# Altium schematic sheet Current_and_Relay_Sense.SchDoc
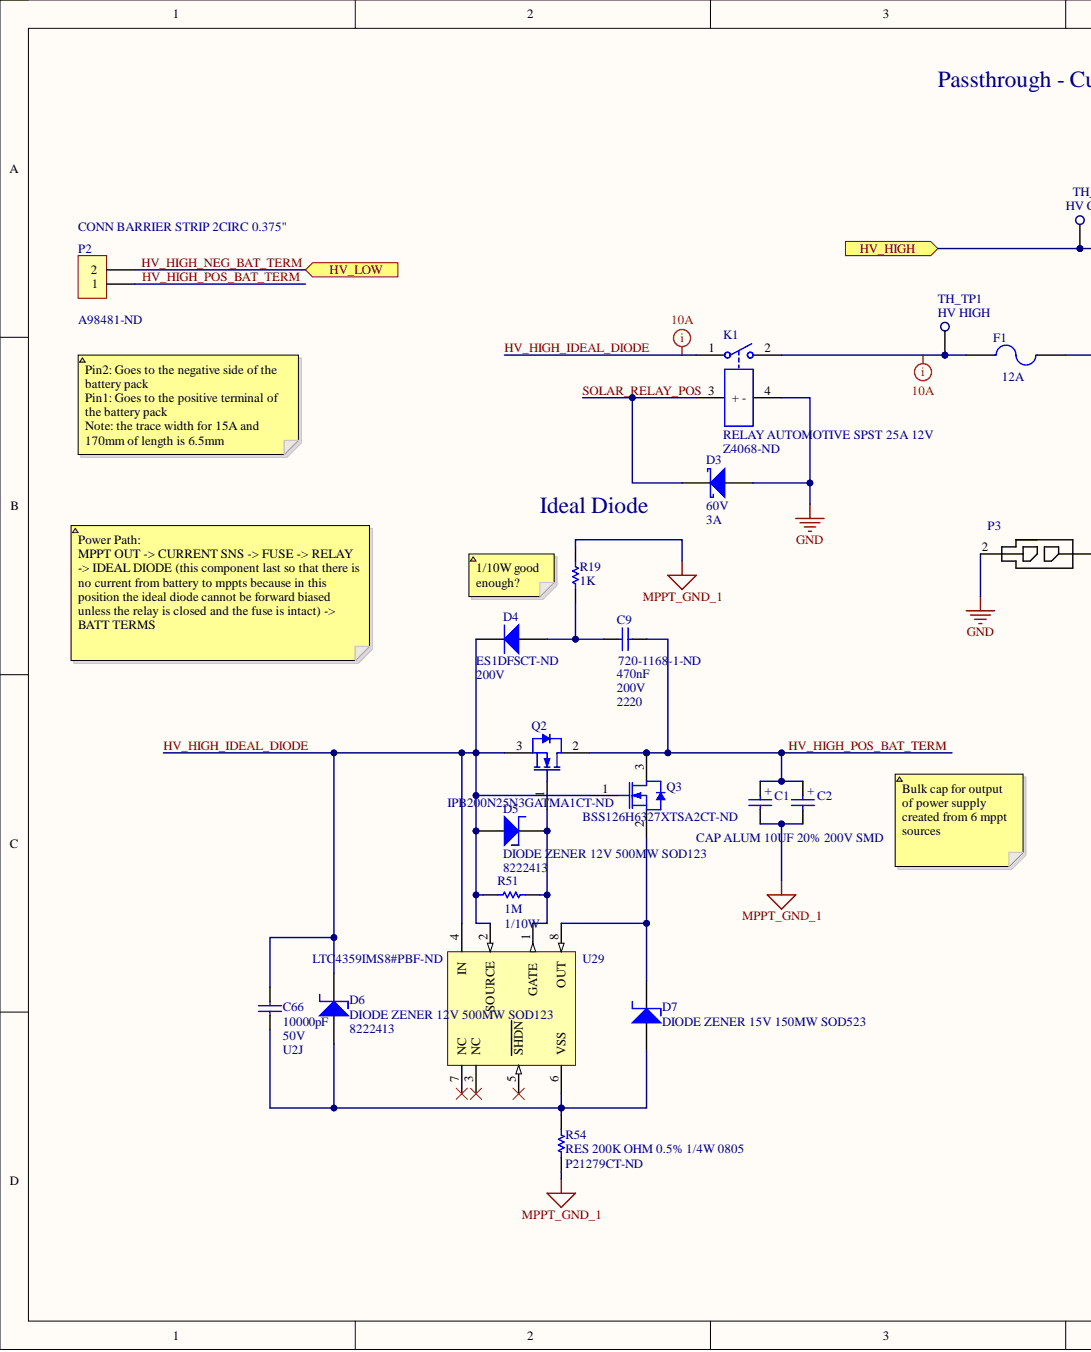
[diagram p0: Current_and_Relay_Sense.SchDoc, left]
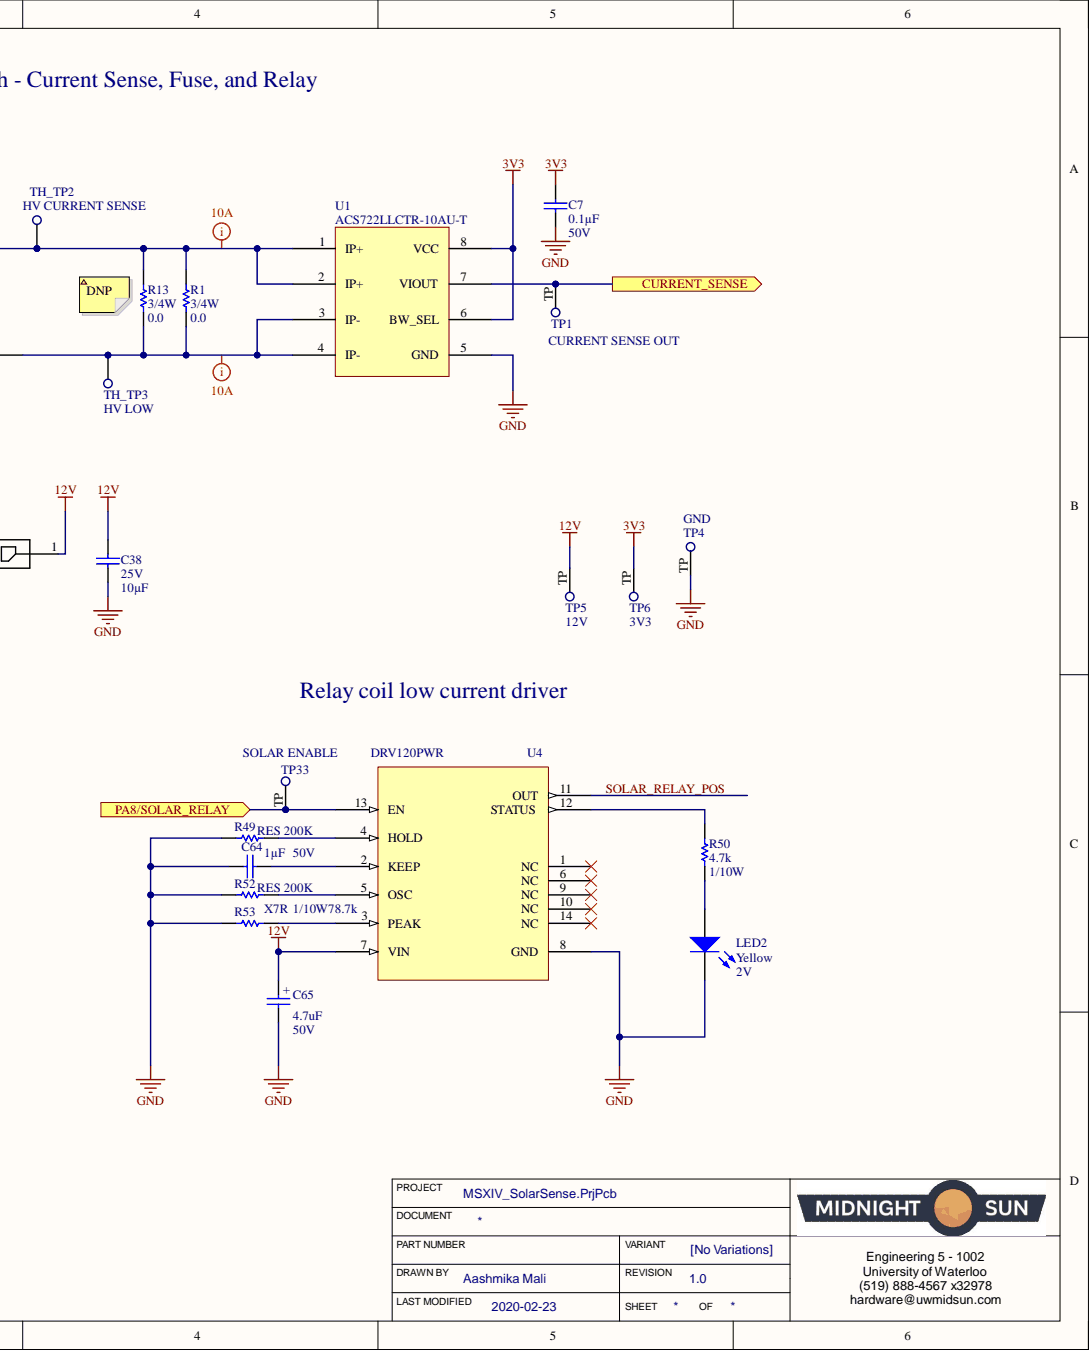
[diagram p1: Current_and_Relay_Sense.SchDoc, right]

## Parts
C1  CAP ALUM 10UF 20% 200V SMD  [CAP ALUM 10MMx10MM UUB2D100MNL1GS]
C2  CAP ALUM 10UF 20% 200V SMD  Tolerance: 20%  Voltage Rating: 200V  [CAP ALUM 10MMx10MM UUB2D100MNL1GS]
C7  Capacitance: 0.1µF  Voltage: 50V  [CAP, 0603]  (hidden comment: CAP CER 0.1UF 50V 10% X7R 0603)
C9  Capacitance: 470nF  Supplier Part Number 1: 720-1168-1-ND  Voltage Rating: 200V  [CAP, 2220]  (hidden comment: CAP CER 0.47UF 200V X7R 2220)
C38  Capacitance: 10µF  Voltage - Rated: 25V  [CAP, 0805]  (hidden comment: CAP CER 10uF 25V 10% X5R 0805)
C64  Capacitance: 1µF  Voltage: 50V  [CAP, 0603]  (hidden comment: CAP CER 1UF 50V 10% X7R 0603)
C65  Capacitance: 4.7uF  Voltage Rating: 50V  [CAP ALUM 6.3MM RADIAL SMD]  (hidden comment: EEE-1HA4R7NP)
C66  Capacitance: 10000pF  Temperature Coefficient: U2J  Voltage: 50V  [CAP, 0603]  (hidden comment: CAP CER 10nF 50V 5% X7R 0603)
D3  Current - Average Rectified (Io): 3A  Voltage - DC Reverse (Vr) (Max): 60V  [Diode, SMA]  (hidden comment: DIODE SCHOTTKY 60V 3A SMA)
D4  Max Repetitive Reverse Voltage (Vrrm): 200V  Supplier Part Number 1: ES1DFSCT-ND  [FAIR-SMA-2_V]  (hidden comment: DIODE GEN PURP 200V 1A SMA)
D5  DIODE ZENER 12V 500MW SOD123  [SOD123]
D6  DIODE ZENER 12V 500MW SOD123  Supplier Part Number 1: 8222413  [SOD123]
D7  DIODE ZENER 15V 150MW SOD523  [SOD-523]
F1  Current Rating: 12A  [FUSE CLIPS 1A3400-09-R]  (hidden comment: FUSE 12 A 600VAC/DC KLM-12)
K1  RELAY AUTOMOTIVE SPST 25A 12V  [RELAY AUTOMOTIVE SPST 25A 12V]
LED2  Color: Yellow  Voltage - Forward (Vf) (Typ): 2V  [LED_0603]  (hidden comment: LED YELLOW CLEAR 2.1V 0603)
P2  CONN BARRIER STRIP 2CIRC 0.375"  Supplier Part Number 1: A98481-ND  [TE_3-1437652-9]
P3  CONN 2POS MICRO-FIT 3mm  [CONN, 2POS MICRO-FIT]  (value not drawn)
Q2  Supplier Part Number 1: IPB200N25N3GATMA1CT-ND  [INF-PG-TO-263-3-2_A_M]  (hidden comment: MOSFET N-CH 250V 64A TO263-3)
Q3  Supplier Part Number 1: BSS126H6327XTSA2CT-ND  [INF-PG-SOT23_M]  (hidden comment: MOSFET N-CH 600V 0.021A SOT-2326)
R1  Power (Watts): 3/4W  Resistance: 0.0  [RES 1206]  (hidden comment: RES 0.0 OHM 3/4W 1206)
R13  Power (Watts): 3/4W  Resistance: 0.0  [RES 1206]  (hidden comment: RES 0.0 OHM 3/4W 1206)
R19  1K  [RES, 0603]
R49  RES 200K  [0805]
R50  Power (Watts): 1/10W  Resistance (Ohms): 4.7k  [RES, 0603]  (hidden comment: RES 4.7K OHM 1% 1/10W 0603)
R51  Resistance (Ohms): 1M  [RES, 0603]  (hidden comment: RES 1M OHM 1% 1/10W 0603)
R52  RES 200K  [0805]
R53  RES 78.7K OHM 1% 1/10W 0603  [RES, 0603]  (value not drawn)
R54  RES 200K OHM 0.5% 1/4W 0805  Supplier Part Number 1: P21279CT-ND  [0805]
TH_TP1  HV HIGH  [Thru Test Point]
TH_TP2  HV CURRENT SENSE  [Thru Test Point]
TH_TP3  HV LOW  [Thru Test Point]
TP1  CURRENT SENSE OUT  [Test Point]  (no BOM)
TP4  GND  [Test Point]  (no BOM)
TP5  12V  [Test Point]  (no BOM)
TP6  3V3  [Test Point]  (no BOM)
TP33  SOLAR ENABLE  [Test Point]  (no BOM)
U1  Manufacturer Part Number: ACS722LLCTR-10AU-T  [SOIC8]  (hidden comment: IC CURRENT SENSOR HALL EFFECT 8-SOIC)
U4  Manufacturer Part Number: DRV120PWR  [PW14]
U29  Supplier Part Number 1: LTC4359IMS8#PBF-ND  [LT-MS8-8_N]  (hidden comment: IC LTC4359HS8#PBF IDEAL DIODE CONTROLLER WITH REVE)

## Ports
CURRENT_SENSE, HV_HIGH, HV_LOW, PA8/SOLAR_RELAY

## Nets
3V3: C7.1 TP6.TP U1.6(BW_SEL) U1.8(VCC)
12V: C38.1 C65.1 P3.1 TP5.TP U4.7(VIN)
GND: C7.2 C38.2 C64.1 C65.2 D3.1 K1.4(-) LED2.2 P3.2 R49.1 R52.1 R53.1 TP4.TP U1.5(GND) U4.8(GND)
MPPT_GND_1: C1.2 C2.2 R19.1 R54.2
CURRENT_SENSE: TP1.TP U1.7(VIOUT) ; port CURRENT_SENSE
HV_HIGH: R1.1 R13.1 TH_TP2.TH_TP U1.1(IP+) U1.2(IP+) ; port HV_HIGH
HV_HIGH_IDEAL_DIODE: C66.1 D4.2 D5.2 D6.1 K1.1 Q2.3 Q3.1 R51.1 U29.2(SOURCE) U29.4(IN)
HV_HIGH_NEG_BAT_TERM: P2.2 ; port HV_LOW
HV_HIGH_POS_BAT_TERM: C1.1 C2.1 C9.2 P2.1 Q2.2 Q3.3
PA8/SOLAR_RELAY: TP33.TP U4.13(EN) ; port PA8/SOLAR_RELAY
SOLAR_RELAY_POS: D3.2 K1.3(+) U4.11(OUT)
NetC9_1: C9.1 D4.1 R19.2
NetC64_2: C64.2 U4.2(KEEP)
NetC66_2: C66.2 D6.2 D7.1 R54.1 U29.6(VSS)
NetD5_1: D5.1 Q2.1 R51.2 U29.1(GATE)
NetD7_2: D7.2 Q3.2 U29.8(OUT)
NetF1_1: F1.1 K1.2 TH_TP1.TH_TP
NetF1_2: F1.2 R1.2 R13.2 TH_TP3.TH_TP U1.3(IP-) U1.4(IP-)
NetLED2_1: LED2.1 R50.2
NetR49_2: R49.2 U4.4(HOLD)
NetR50_1: R50.1 U4.12(STATUS)
NetR52_2: R52.2 U4.5(OSC)
NetR53_2: R53.2 U4.3(PEAK)

Not connected: U4 1(NC) 6(NC) 9(NC) 10(NC) 14(NC); U29 3(NC) 5(SHDN) 7(NC)

## Library data of the parts
C1 C2 = CAP ALUM 10UF 20% 200V SMD: Cap Aluminum 10uF 200V 20% (10 X 10mm) SMD Cylindrical 59mA 2000 hr 125°C T/R; Manufacturer: Nichicon; Manufacturer Part Number: UUB2D100MNL1GS; Leakage Current: 100uA; Life (Hours): 2000Hour; Capacitance: 10uF; Case/Package: Aluminum; Category: Aluminum Electrolytic Capacitors; Component Kind: Standard; ComponentLink1Description: https://datasheet.ciiva.com/15029/e-ub-15029349.pdf?src-supplier=Digi-Key; ComponentLink1URL: https://datasheet.ciiva.com/15029/e-ub-15029349.pdf?src-supplier=Digi-Key; ComponentLink2Description: https://datasheet.ciiva.com/15029/e-ub-15029350.pdf?src-supplier=Digi-Key; ComponentLink2URL: https://datasheet.ciiva.com/15029/e-ub-15029350.pdf?src-supplier=Digi-Key; Diameter: 10mm; Features: General Purpose; Height: 10mm; Height - Seated (Max): 0.394inch; Length: 10mm; Max Operating Temperature: 125°C; Mount: Surface Mount; Package Quantity: 500; Packaging: Tape and Reel; Pricing 1: 1=0.80000, 10=0.60600, 100=0.43380 (USD); Radiation Hardening: No; Ripple Current: 59mA; RoHS: Yes; Stock 1: 15148; Supplier 1: Digi-Key; Supplier Part Number 1: 493-9962-1-ND; Termination: SMD/SMT; Voltage Rating (DC): 200V; Library Name: Schematic Diagrams.SchLib
  C1: Tolerance: 20%; Voltage Rating: 200V
C7 = CAP CER 0.1UF 50V 10% X7R 0603: 0.10µF ±10% 50V Ceramic Capacitor X7R 0603 (1608 Metric); Manufacturer 1: AVX Corporation; Manufacturer Part Number 1: 06035C104KAT2A; Component Kind: Standard; ComponentLink1Description: http://datasheets.avx.com/X7RDielectric.pdf; ComponentLink1URL: http://datasheets.avx.com/X7RDielectric.pdf; Height - Seated (Max): -; Lead Spacing: -; Lead Style: -; Mounting Type: Surface Mount, MLCC; Operating Temperature: -55°C ~ 125°C; Package / Case: 0603 (1608 Metric); Packaging: Cut Tape (CT); Part Status: Active; Ratings: -; Size / Dimension: 0.063" L x 0.032" W (1.60mm x 0.81mm); Supplier 1: Digi-Key; Supplier Part Number 1: 478-5052-1-ND; Temperature Coefficient: X7R; Thickness (Max): 0.035" (0.90mm); Tolerance: ±10%; Library Name: Schematic Diagrams.SchLib
C9 = CAP CER 0.47UF 200V X7R 2220: Manufacturer: Vishay Vitramon; Manufacturer Part Number: VJ2220Y474KXCAT; Tolerance: 10%; Case Code (Imperial): 2220; Case Code (Metric): 5750; Case/Package: 2220; Category: Ceramic Capacitors; Component Kind: Standard; ComponentLink1Description: https://datasheet.ciiva.com/149/vjcommercialseries-149446.pdf?src-supplier=Digi-Key; ComponentLink1URL: https://datasheet.ciiva.com/149/vjcommercialseries-149446.pdf?src-supplier=Digi-Key; ComponentLink2Description: https://datasheet.ciiva.com/149/vjcommercialseries-149447.pdf?src-supplier=Digi-Key; ComponentLink2URL: https://datasheet.ciiva.com/149/vjcommercialseries-149447.pdf?src-supplier=Digi-Key; ComponentLink3Description: https://datasheet.ciiva.com/149/vjcommercialseries-149445.pdf?src-supplier=Digi-Key; ComponentLink3URL: https://datasheet.ciiva.com/149/vjcommercialseries-149445.pdf?src-supplier=Digi-Key; Depth: 5.08mm; Description: CAP CER 0.47UF 200V X7R 2220; Dielectric: X7R; Length: 0.22inch; Max Operating Temperature: 125°C; Min Operating Temperature: -55°C; Mount: Surface Mount; Package Quantity: 1; Packaging: Tape and Reel; Pricing 1: 1=1.64000, 10=1.29800, 100=0.97370, 500=0.73566 (USD); RoHS: Yes; Stock 1: 868; Supplier 1: Digi-Key; Thickness: 0.086inch; Voltage Rating (DC): 200V; Width: 0.2inch; Library Name: Schematic Diagrams.SchLib
C38 = CAP CER 10uF 25V 10% X5R 0805: 10µF ±10% 25V Ceramic Capacitor X5R 0805 (2012 Metric); Manufacturer: Murata Electronics North America; Manufacturer Part Number: GRM21BR61E106KA73L; Applications: General Purpose; Category: Capacitors; Component Kind: Standard; ComponentLink1Description: http://search.murata.co.jp/Ceramy/image/img/A01X/G101/ENG/GRM21BR61E106KA73-01.pdf; ComponentLink1URL: http://search.murata.co.jp/Ceramy/image/img/A01X/G101/ENG/GRM21BR61E106KA73-01.pdf; Features: -; Height - Seated (Max): -; Lead Spacing: -; Lead Style: -; Mounting Type: Surface Mount, MLCC; Operating Temperature: -55°C ~ 85°C; Package / Case: 0805 (2012 Metric); Packaging: Cut Tape (CT); Part Status: Active; Pricing 1: 1=0.3, 10=0.214, 100=0.1008, 500=0.07068, 1000=0.05851 (CAD); Ratings: -; RoHS: RoHS Compliant; Size / Dimension: 0.079" L x 0.049" W (2.00mm x 1.25mm); Stock 1: 1695157; Supplier 1: Digi-Key; Supplier Part Number 1: 490-5523-1-ND; Temperature Coefficient: X5R; Thickness (Max): 0.053" (1.35mm); Tolerance: ±10%; Library Name: Schematic Diagrams.SchLib
C64 = CAP CER 1UF 50V 10% X7R 0603: 1µF ±10% 50V Ceramic Capacitor X7R 0603 (1608 Metric); Manufacturer 1: Taiyo Yuden; Manufacturer Part Number 1: UMK107AB7105KA-T; Component Kind: Standard; ComponentLink1Description: http://www.yuden.co.jp/productdata/catalog/en/mlcc_all_e.pdf; ComponentLink1URL: http://www.yuden.co.jp/productdata/catalog/en/mlcc_all_e.pdf; Height - Seated (Max): -; Lead Spacing: -; Lead Style: -; Mounting Type: Surface Mount, MLCC; Operating Temperature: -55°C ~ 125°C; Package / Case: 0603 (1608 Metric); Packaging: Cut Tape (CT); Part Status: Active; Ratings: -; Size / Dimension: 0.063" L x 0.031" W (1.60mm x 0.80mm); Supplier 1: Digi-Key; Supplier Part Number 1: 587-3247-1-ND; Temperature Coefficient: X7R; Thickness (Max): 0.037" (0.95mm); Tolerance: ±10%; Library Name: Schematic Diagrams.SchLib
C65 = CAP ALUM 4.7UF 20% 50V SMD: 4.7µF 50V Aluminum Electrolytic Capacitors Radial, Can - SMD 2000 Hrs @ 85°C; Manufacturer 1: Panasonic; Description 1: CAPACITOR ALUM ELEC, 4.7UF, 50V, 20%, SMD; Life (Hours): 2000Hour; Ripple Current: 31mA; Tolerance: 20%; Case/Package: Radial; Component Kind: Standard; ComponentLink1Description: https://datasheet.ciiva.com/46374/aba0000ce2-46374373.pdf; ComponentLink1URL: https://datasheet.ciiva.com/46374/aba0000ce2-46374373.pdf; ComponentLink2Description: https://datasheet.ciiva.com/14600/70255710-14600343.pdf; ComponentLink2URL: https://datasheet.ciiva.com/14600/70255710-14600343.pdf; ComponentLink3Description: https://datasheet.ciiva.com/17536/aba0000ce2-17536647.pdf; ComponentLink3URL: https://datasheet.ciiva.com/17536/aba0000ce2-17536647.pdf; ComponentLink4Description: https://datasheet.ciiva.com/24027/aba0000ce2-40801-24027083.pdf; ComponentLink4URL: https://datasheet.ciiva.com/24027/aba0000ce2-40801-24027083.pdf; ComponentLink5Description: https://datasheet.ciiva.com/31414/aba0000ce2-31414684.pdf; ComponentLink5URL: https://datasheet.ciiva.com/31414/aba0000ce2-31414684.pdf; ComponentLink6Description: https://datasheet.ciiva.com/14606/70255710-14606079.pdf; ComponentLink6URL: https://datasheet.ciiva.com/14606/70255710-14606079.pdf; Diameter: 6.3mm; Height: 5.4mm; Height - Seated (Max): 0.213inch; Lead Free: Lead Free; Length: 5.5mm; Manufacturer Part Number 1: EEE-1HA4R7NP; Max Operating Temperature: 85°C; Min Operating Temperature: -40°C; Mount: Surface Mount,PCB; Package Quantity: 1; Packaging: Tape and Reel; Pricing 1: 1=0.43, 10=0.302, 100=0.1986, 500=0.1471 (USD); Radiation Hardening: No; REACH SVHC: No SVHC; Ripple Current (AC): 31mA; Stock 1: 19771; Supplier 1: Digi-Key; Supplier Part Number 1: PCE4304CT-ND; Termination: SMD/SMT; Voltage Rating (DC): 50V; Width: 650um; Library Name: Schematic Diagrams.SchLib
C66 = CAP CER 10nF 50V 5% X7R 0603: 10000pF ±5% 50V Ceramic Capacitor U2J 0603 (1608 Metric); Manufacturer 1: KEMET; Manufacturer Part Number 1: C0603C103J5JAC7867; Component Kind: Standard; ComponentLink1Description: http://www.kemet.com/docfinder?Partnumber=C0603C103J5JAC7867; ComponentLink1URL: http://www.kemet.com/docfinder?Partnumber=C0603C103J5JAC7867; Failure Rate: -; Height - Seated (Max): -; Lead Spacing: -; Lead Style: -; Mounting Type: Surface Mount, MLCC; Operating Temperature: -55°C ~ 125°C; Package / Case: 0603 (1608 Metric); Packaging: Cut Tape (CT); Part Status: Active; Ratings: -; Size / Dimension: 0.063" L x 0.032" W (1.60mm x 0.80mm); Supplier 1: Digi-Key; Supplier Part Number 1: 399-13384-1-ND; Thickness (Max): 0.034" (0.87mm); Tolerance: ±5%; Library Name: Schematic Diagrams.SchLib
D3 = DIODE SCHOTTKY 60V 3A SMA: Diode Schottky 60V 3A Surface Mount SMA; Manufacturer 1: Diodes Incorporated; Manufacturer Part Number 1: B360A-13-F; Capacitance @ Vr, F: 200pF @ 4V, 1MHz; Current - Reverse Leakage @ Vr: 500µA @ 60V; Speed: Fast Recovery =< 500ns, > 200mA (Io); Voltage - Forward (Vf) (Max) @ If: 700mV @ 3A; Component Kind: Standard; ComponentLink1Description: https://www.diodes.com/assets/Datasheets/ds30891.pdf; ComponentLink1URL: https://www.diodes.com/assets/Datasheets/ds30891.pdf; Diode Type: Schottky; Mounting Type: Surface Mount; Operating Temperature - Junction: -55°C ~ 150°C; Package / Case: DO-214AC, SMA; Packaging: Cut Tape (CT); Part Status: Active; Supplier 1: Digi-Key; Supplier Device Package: SMA; Supplier Part Number 1: B360A-FDICT-ND; Library Name: Schematic Diagrams.SchLib
D4 = DIODE GEN PURP 200V 1A SMA: Manufacturer: ON Semiconductor / Fairchild; Manufacturer Part Number: ES1D; Capacitance: 7pF; Element Configuration: Single; Forward Voltage: 920mV; Max Forward Surge Current (Ifsm): 30A; Peak Reverse Current: 5uA; Polarity: Standard; Power Dissipation: 1.47W; Recovery Time: 15ns; Average Rectified Current: 1A; Case/Package: SMA; Category: Rectifier Diodes; Component Kind: Standard; ComponentLink1Description: https://datasheet.ciiva.com/5739/575529-5739201.pdf?src-supplier=Farnell; ComponentLink1URL: https://datasheet.ciiva.com/5739/575529-5739201.pdf?src-supplier=Farnell; ComponentLink2Description: https://datasheet.ciiva.com/4453/es1d-192752-4453197.pdf?src-supplier=Mouser; ComponentLink2URL: https://datasheet.ciiva.com/4453/es1d-192752-4453197.pdf?src-supplier=Mouser; ComponentLink3Description: https://datasheet.ciiva.com/917/es1a-917873.pdf?src-supplier=Digi-Key; ComponentLink3URL: https://datasheet.ciiva.com/917/es1a-917873.pdf?src-supplier=Digi-Key; ComponentLink4Description: https://datasheet.ciiva.com/17041/es1d-17041659.pdf?src-supplier=Future+Electronics; ComponentLink4URL: https://datasheet.ciiva.com/17041/es1d-17041659.pdf?src-supplier=Future+Electronics; ComponentLink5Description: https://datasheet.ciiva.com/7622/575529-7622988.pdf?src-supplier=Element14; ComponentLink5URL: https://datasheet.ciiva.com/7622/575529-7622988.pdf?src-supplier=Element14; ComponentLink6Description: https://datasheet.ciiva.com/917/es1a-917872.pdf?src-supplier=Digi-Key; ComponentLink6URL: https://datasheet.ciiva.com/917/es1a-917872.pdf?src-supplier=Digi-Key; ComponentLink7Description: https://datasheet.ciiva.com/25951/es1d-25951451.pdf?src-supplier=Avnet+Europe; ComponentLink7URL: https://datasheet.ciiva.com/25951/es1d-25951451.pdf?src-supplier=Avnet+Europe; ComponentLink8Description: https://datasheet.ciiva.com/8300/es1d-8300557.pdf?src-supplier=Avnet; ComponentLink8URL: https://datasheet.ciiva.com/8300/es1d-8300557.pdf?src-supplier=Avnet; ComponentLink9Description: https://datasheet.ciiva.com/917/es1a-917871.pdf?src-supplier=Digi-Key; ComponentLink9URL: https://datasheet.ciiva.com/917/es1a-917871.pdf?src-supplier=Digi-Key; Contact Plating: Tin,Matte; Current: 1A; Current Rating: 1A; Description: DIODE GEN PURP 200V 1A SMA; Forward Current: 1A; Height: 2.2mm; Lead Free: Lead Free; Length: 4.75mm; Max Operating Temperature: 150°C; Max Reverse Voltage (DC): 200V; Max Surge Current: 30A; Min Operating Temperature: -50°C; Mount: Surface Mount; Number of Pins: 2; Output Current: 1A; Package Quantity: 1; Packaging: Tape and Reel; Peak Non-Repetitive Surge Current: 30A; Pricing 1: 1=0.36000, 10=0.27000, 25=0.23640, 100=0.12840, 250=0.12768, 500=0.10472, 1000=0.07769, 2500=0.06824 (USD); Radiation Hardening: No; REACH SVHC: No SVHC; Reverse Recovery Time: 15ns; Reverse Voltage: 200V; RoHS: Yes; Stock 1: 804358; Supplier 1: Digi-Key; Voltage: 200V; Voltage Rating (DC): 200V; Width: 2.95mm; Library Name: Schematic Diagrams.SchLib
D5 D6 = DIODE ZENER 12V 500MW SOD123: DIODE ZENER 12V 150MW SOD523; Manufacturer: Diodes; Manufacturer Part Number: DDZ9699DICT-ND; Element Configuration: Single; Forward Voltage: 900mV; Max Reverse Leakage Current: 50nA; Test Current: 50uA; Tolerance: 5%; Case/Package: SOD-523-2; Category: Zener Diodes; Component Kind: Standard; ComponentLink1Description: https://datasheet.ciiva.com/16561/ds30553-16561727.pdf?src-supplier=Avnet+Europe; ComponentLink1URL: https://datasheet.ciiva.com/16561/ds30553-16561727.pdf?src-supplier=Avnet+Europe; Height: 0.65mm; Length: 1.7mm; Max Operating Temperature: 150°C; Max Power Dissipation: 150mW; Min Operating Temperature: -65°C; Mount: Surface Mount; Number of Pins: 2; Package Quantity: 3000; Packaging: Tape and Reel; Peak Reverse Current: 50nA; Power Dissipation: 150mW; Power Rating: 150mW; Pricing 1: 100=0.12100, 600=0.10300, 1500=0.08100 (USD); Radiation Hardening: No; RoHS: Yes; Stock 1: 900; Supplier 1: RSComponents; Voltage Tolerance: 5%; Weight: 3.5E-05oz; Width: 0.9mm; Zener Current: 50nA; Zener Voltage: 12V; Library Name: Schematic Diagrams.SchLib
  D5: Supplier Part Number 1: 8222413
D7 = DIODE ZENER 15V 150MW SOD523: Zener Diodes 150MW 15V; Component Kind: Standard; Library Name: Schematic Diagrams.SchLib
F1 = FUSE 12 A 600VAC/DC KLM-12: FUSE CRTRDGE 12A 600VAC/VDC 5AG; Manufacturer: Eaton; Approvals: CE, CSA, UL; Breaking Capacity @ Rated Voltage: 100kA AC, 50kA DC; Fuse Type: Cartridge; Response Time: Fast; Applications: Electrical, Industrial; Category: Circuit Protection; Class: -; Component Kind: Standard; ComponentLink1Description: http://www.cooperindustries.com/content/dam/public/bussmann/Electrical/Resources/product-datasheets-a/Bus_Ele_DS_2020_KLM.pdf; ComponentLink1URL: http://www.cooperindustries.com/content/dam/public/bussmann/Electrical/Resources/product-datasheets-a/Bus_Ele_DS_2020_KLM.pdf; Features: -; Manufacturer Part Number: KLM-12; Mounting Type: Holder; Operating Temperature: -; Package / Case: 5AG, 10mm x 38.1mm; Packaging: Bulk; Part Status: Active; Pricing 1: 10=39.155 (CAD); RoHS: RoHS Compliant; Size / Dimension: 0.406" Dia x 1.500" L (10.30mm x 38.10mm); Stock 1: 0; Supplier 1: Digi-Key; Supplier Part Number 1: KLM-12-ND; Library Name: Schematic Diagrams.SchLib
K1 = RELAY AUTOMOTIVE SPST 25A 12V: Manufacturer: Omron; Manufacturer Part Number: G9EJ-1-DC12; Coil Current: 167mA; Coil Power: 2W; Coil Resistance: 72R; Coil Type: Non-Latching; Max Voltage Rating (AC): 400V; Operate Time: 50ms; Release Time: 30ms; Category: Power Relays; Coil Voltage (DC): 12V; Component Kind: Standard; ComponentLink1Description: https://datasheet.ciiva.com/24086/g9ej-0613-258278-24086896.pdf?src-supplier=Mouser; ComponentLink1URL: https://datasheet.ciiva.com/24086/g9ej-0613-258278-24086896.pdf?src-supplier=Mouser; ComponentLink2Description: https://datasheet.ciiva.com/29981/g9ej-0613-29981763.pdf?src-supplier=Avnet; ComponentLink2URL: https://datasheet.ciiva.com/29981/g9ej-0613-29981763.pdf?src-supplier=Avnet; ComponentLink3Description: https://datasheet.ciiva.com/18528/en-g9ej-18528889.pdf?src-supplier=Digi-Key; ComponentLink3URL: https://datasheet.ciiva.com/18528/en-g9ej-18528889.pdf?src-supplier=Digi-Key; ComponentLink4Description: https://datasheet.ciiva.com/30097/g9ej-0613-30097130.pdf?src-supplier=Onlinecomponents.com; ComponentLink4URL: https://datasheet.ciiva.com/30097/g9ej-0613-30097130.pdf?src-supplier=Onlinecomponents.com; Contact Current Rating: 25A; Description: RELAY AUTOMOTIVE SPST 25A 12V; Height: 30mm; Length: 31mm; Max Current Rating: 25A; Max Operating Temperature: 85°C; Max Voltage Rating (DC): 400V; Min Operating Temperature: -40°C; Mount: Flanges,Socketable,Plug-In; Number of Pins: 4; Package Quantity: 10; Packaging: Bulk; Power Consumption: 2W; Pricing 1: 1=31.00000, 10=29.45000, 25=27.90000, 50=26.35000, 100=24.80000, 250=23.25000 (USD); Radiation Hardening: No; Relay Type: Automotive; RoHS: Yes; Stock 1: 391; Supplier 1: Digi-Key; Supplier Part Number 1: Z4068-ND; Switching Voltage: 400V; Termination: Quick Connect; Throw Configuration: SPST; Weight: 50g; Width: 27mm; Library Name: Schematic Diagrams.SchLib
LED2 = LED YELLOW CLEAR 2.1V 0603: Yellow 590nm LED Indication - Discrete 2V 0603 (1608 Metric); Manufacturer: Wurth Electronics Inc.; Manufacturer Part Number: 150060YS75000; Current - Test: 20mA; Lens Color: Colorless; Lens Style/Size: Rectangle with Flat Top, 1.10mm x 0.70mm; Millicandela Rating: 120mcd; Viewing Angle: 140°; Wavelength - Peak: 595nm; Category: Optoelectronics; Component Kind: Standard; ComponentLink1Description: http://katalog.we-online.de/led/datasheet/150060YS75000.pdf; ComponentLink1URL: http://katalog.we-online.de/led/datasheet/150060YS75000.pdf; Features: -; Height (Max): 0.80mm; Lens Transparency: Clear; Mounting Type: Surface Mount; Package / Case: 0603 (1608 Metric); Packaging: Cut Tape (CT); Part Status: Active; Pricing 1: 1=0.21, 100=0.1617, 500=0.13964 (CAD); RoHS: RoHS Compliant; Size / Dimension: 1.60mm L x 0.80mm W; Stock 1: 10523; Supplier 1: Digi-Key; Supplier Device Package: 0603; Supplier Part Number 1: 732-4981-1-ND; Wavelength - Dominant: 590nm; Library Name: Schematic Diagrams.SchLib
P2 = CONN BARRIER STRIP 2CIRC 0.375": Barrier Terminal Blocks 2P TRI-BARRIER .375; Manufacturer: TE Connectivity; Manufacturer Part Number: 6PCV-02-006; Current Rating: 5A; Max Current Rating: 25A; Mounting Ears: No (Without); Number of Circuits: 2; Number of Levels: 1; Pitch: 9.53mm; Voltage: 300V; Wire Gauge (Max): 12AWG; Wire Gauge (Min): 22AWG; Category: Terminal Blocks; Color: Black; Component Kind: Standard; ComponentLink1Description: https://datasheet.ciiva.com/7978/1650932-7978603.pdf?src-supplier=Element14; ComponentLink1URL: https://datasheet.ciiva.com/7978/1650932-7978603.pdf?src-supplier=Element14; Contact Material: Copper Alloy; Contact Plating: Tin; ELV: Compliant; Flammability Rating: UL94 V-0; Height: 0.625inch; Housing Color: Black; Housing Material: Thermoplastic; Length: 0.811inch; Mount: Through Hole; Mounting Insert: No (Without); Number of Contacts: 2; Number of Positions: 2; Number of Rows: 1; Orientation: Straight; Package Quantity: 270; Packaging: Bulk; Pricing 1: 1=1.63000, 10=1.14400, 25=1.06680, 50=0.96820, 100=0.96400, 250=0.81404, 500=0.77122, 1000=0.68552, 5000=0.55699 (USD); REACH SVHC: Unknown; RoHS: Yes; Stock 1: 8088; Supplier 1: Digi-Key; Terminal Material: Copper Alloy; Termination: Solder; Voltage Rating: 300V; Width: 0.7inch; Wire/Cable Gauge: 22AWG; Library Name: Schematic Diagrams.SchLib
P3 = CONN 2POS MICRO-FIT 3mm: Connector Header Through Hole 2 position; Manufacturer: Molex; Manufacturer Part Number: 43045-0227; Contact Resistance: 10mR; Current Rating: 5A; Gender: Male; Glow Wire Compliant: Compliant; Max Voltage Rating (DC): 250.25kV; Number of Circuits: 2; Number of Ports: 1; Voltage Rating: 600V; Component Kind: Standard; ComponentLink1Description: http://www.onlinecomponents.com/productFiles/mf-MOL/307170430450227_pcb_headers.pdf; ComponentLink1URL: http://www.onlinecomponents.com/productFiles/mf-MOL/307170430450227_pcb_headers.pdf; ComponentLink2Description: http://www.mouser.com/ds/2/276/0430450227_PCB_HEADERS-142611.pdf; ComponentLink2URL: http://www.mouser.com/ds/2/276/0430450227_PCB_HEADERS-142611.pdf; ComponentLink3Description: http://uk.rs-online.com/webdocs/13c3/0900766b813c3a46.pdf; ComponentLink3URL: http://uk.rs-online.com/webdocs/13c3/0900766b813c3a46.pdf; ComponentLink4Description: http://www.molex.com/webdocs/datasheets/pdf/en-us/0430450227_PCB_HEADERS.pdf; ComponentLink4URL: http://www.molex.com/webdocs/datasheets/pdf/en-us/0430450227_PCB_HEADERS.pdf; Contact Material: Brass; Contact Plating: Tin; Depth: 8.77mm; Halogen Free: Low Halogen; Housing Colour: Black; Housing Material: Thermoplastic; Length: 7.15mm; Max Operating Temperature: 105°C; Min Operating Temperature: -40°C; Mount: Through Hole; Number of Contacts: 2; Number of Positions: 2; Number of Rows: 2; Number of Terminals: 2; Orientation: Vertical; Package Quantity: 3168; Packaging: Tray; Pitch: 3mm; Pricing 1: 1=0.84, 10=0.74, 25=0.6968, 50=0.6676, 100=0.6387, 250=0.5806, 500=0.52254, 1000=0.46448, 2500=0.42094 (USD); Radiation Hardening: No; Row Spacing: 3mm; Stock 1: 3657; Supplier 1: Digi-Key; Supplier Part Number 1: WM10657-ND; Termination: Solder; Library Name: Schematic Diagrams.SchLib
Q2 = MOSFET N-CH 250V 64A TO263-3: Manufacturer: Infineon; Manufacturer Part Number: IPB200N25N3G; Drain to Source Resistance: 20mR; Fall Time: 12ns; Gate to Source Voltage (Vgs): 20V; Input Capacitance: 7.1nF; Max Power Dissipation: 300W; Rise Time: 20ns; Threshold Voltage: 3V; Turn-Off Delay Time: 45ns; Turn-On Delay Time: 18ns; Case/Package: TO-263-3; Category: MOSFETs; Component Kind: Standard; ComponentLink1Description: https://datasheet.ciiva.com/11171/infineon-ipp-b-i-200n25n3-g-ds-v02-04-en-11171616.pdf?src-supplier=Avnet; ComponentLink1URL: https://datasheet.ciiva.com/11171/infineon-ipp-b-i-200n25n3-g-ds-v02-04-en-11171616.pdf?src-supplier=Avnet; ComponentLink2Description: https://datasheet.ciiva.com/26789/ipb200n25n3-g-26789555.pdf?src-supplier=IIIC; ComponentLink2URL: https://datasheet.ciiva.com/26789/ipb200n25n3-g-26789555.pdf?src-supplier=IIIC; ComponentLink3Description: https://datasheet.ciiva.com/942/infineon-ipp-b-i-200n25n3-g-ds-v02-04-en-942442.pdf?src-supplier=Digi-Key; ComponentLink3URL: https://datasheet.ciiva.com/942/infineon-ipp-b-i-200n25n3-g-ds-v02-04-en-942442.pdf?src-supplier=Digi-Key; ComponentLink4Description: https://datasheet.ciiva.com/942/infineon-ipp-b-i-200n25n3-g-ds-v02-04-en-942443.pdf?src-supplier=Digi-Key; ComponentLink4URL: https://datasheet.ciiva.com/942/infineon-ipp-b-i-200n25n3-g-ds-v02-04-en-942443.pdf?src-supplier=Digi-Key; ComponentLink5Description: https://datasheet.ciiva.com/942/infineon-ipp-b-i-200n25n3-g-ds-v02-04-en-942444.pdf?src-supplier=Digi-Key; ComponentLink5URL: https://datasheet.ciiva.com/942/infineon-ipp-b-i-200n25n3-g-ds-v02-04-en-942444.pdf?src-supplier=Digi-Key; Continuous Drain Current (ID): 64A; Description: MOSFET N-CH 250V 64A TO263-3; Drain to Source Breakdown Voltage: 250V; Drain to Source Voltage (Vdss): 250V; Halogen Free: Halogen Free; Lead Free: Lead Free; Max Operating Temperature: 175°C; Min Operating Temperature: -55°C; Number of Pins: 3; Package Quantity: 1000; Packaging: Tape and Reel; Power Dissipation: 300W; Pricing 1: 1=6.36000, 10=5.74600, 25=5.47880, 100=4.54350, 250=4.27624, 500=4.00896 (USD); Rds On Max: 20mR; REACH SVHC: No SVHC; RoHS: Yes; Stock 1: 1971; Supplier 1: Digi-Key; Library Name: Schematic Diagrams.SchLib
Q3 = BSS1MOSFET N-CH 600V 0.021A SOT-2326: Infineon BSS126 N-channel MOSFET Transistor, 0.021 A, 600 V Depletion, 3-Pin SOT-23; Continuous Drain Current (ID): 17mA; Drain to Source Resistance: 700R; Element Configuration: Single; Gate to Source Voltage (Vgs): 20V; Input Capacitance: 21pF; Max Power Dissipation: 500mW; Rds On Max: 700mR; Turn-Off Delay Time: 14ns; Turn-On Delay Time: 6.1ns; Case/Package: SOT-23; Component Kind: Standard; ComponentLink1Description: https://datasheet.ciiva.com/25625/0900766b81074047-25625305.pdf?src-supplier=RSComponents; ComponentLink1URL: https://datasheet.ciiva.com/25625/0900766b81074047-25625305.pdf?src-supplier=RSComponents; Drain to Source Voltage (Vdss): 600V; Halogen Free: Halogen Free; Height: 1mm; Length: 2.9mm; Manufacturer: Infineon; Manufacturer Part Number: BSS126; Max Dual Supply Voltage: 600V; Max Operating Temperature: 150°C; Min Operating Temperature: -55°C; Number of Pins: 3; Packaging: Tape and Reel; Pricing 1: 1=0.41000, 10=0.35300, 25=0.32920, 100=0.24450, 250=0.23228, 500=0.19090, 1000=0.15517 (USD); Resistance: 700R; RoHS: Yes; Stock 1: 1746; Supplier 1: Digi-Key; Width: 1.3mm; Library Name: Schematic Diagrams.SchLib
R1 R13 = RES 0.0 OHM 3/4W 1206: 0 Ohms Jumper 0.75W, 3/4W Chip Resistor 1206 (3216 Metric) Automotive AEC-Q200 Metal Foil; Manufacturer: Stackpole Electronics Inc.; Manufacturer Part Number: HCJ1206ZT0R00; Category: Resistors; Component Kind: Standard; ComponentLink1Description: https://www.seielect.com/catalog/SEI-HCJ.pdf; ComponentLink1URL: https://www.seielect.com/catalog/SEI-HCJ.pdf; Composition: Metal Foil; Failure Rate: -; Features: Automotive AEC-Q200; Height - Seated (Max): 0.032" (0.80mm); Operating Temperature: -55°C ~ 155°C; Package / Case: 1206 (3216 Metric); Packaging: Cut Tape (CT); Part Status: Active; Pricing 1: 1=0.82, 10=0.583, 25=0.4552, 50=0.3852, 100=0.3034, 250=0.25676, 500=0.21006, 1000=0.16337 (CAD); RoHS: RoHS Compliant; Size / Dimension: 0.122" L x 0.061" W (3.10mm x 1.55mm); Stock 1: 1029426; Supplier 1: Digi-Key; Supplier Device Package: 1206; Supplier Part Number 1: HCJ1206ZT0R00CT-ND; Temperature Coefficient: -; Tolerance: Jumper; Library Name: Schematic Diagrams.SchLib
R19 = RES 1K OHM 5% 1/10W 0603: 1k Ohm ±5% 0.1W, 1/10W Chip Resistor 0603 (1608 Metric); Manufacturer: Yageo; Manufacturer Part Number: RC0603JR-071KL; Temperature Coefficient: ±100ppm/°C; Category: Resistors; Component Kind: Standard; ComponentLink1Description: http://www.yageo.com/documents/recent/PYu-RC_Group_51_RoHS_L_7.pdf; ComponentLink1URL: http://www.yageo.com/documents/recent/PYu-RC_Group_51_RoHS_L_7.pdf; Composition: Thick Film; Failure Rate: -; Features: Moisture Resistant; Height - Seated (Max): 0.022" (0.55mm); Operating Temperature: -55°C ~ 155°C; Package / Case: 0603 (1608 Metric); Packaging: Cut Tape (CT); Part Status: Active; Power (Watts): 0.1W, 1/10W; Pricing 1: 1=0.15, 10=0.017, 100=0.0071, 1000=0.0032, 2500=0.00278 (CAD); Resistance (Ohms): 1k; RoHS: RoHS Compliant; Size / Dimension: 0.063" L x 0.031" W (1.60mm x 0.80mm); Stock 1: 4415240; Supplier 1: Digi-Key; Supplier Device Package: 0603; Supplier Part Number 1: 311-1.0KGRCT-ND; Tolerance: ±5%; Library Name: Schematic Diagrams.SchLib
R49 R52 R54 = RES 200K OHM 0.5% 1/4W 0805: RES SMD 200K OHM 0.5% 1/4W 0805; Manufacturer: Panasonic; Manufacturer Part Number: ERJ-PB6D2003V; Max Power Dissipation: 250mW; Resistance: 200kR; Temperature Coefficient: 50ppm/°C; Case Code (Imperial): 0805; Case Code (Metric): 2012; Case/Package: 0805; Category: Chip SMD Resistors; Component Kind: Standard; ComponentLink1Description: https://industrial.panasonic.com/sa/system/files/data/download/catalog/id_fixed-resistors_e_2.pdf?src-supplier=Digi-Key; ComponentLink1URL: https://industrial.panasonic.com/sa/system/files/data/download/catalog/id_fixed-resistors_e_2.pdf?src-supplier=Digi-Key; ComponentLink2Description: https://industrial.panasonic.com/cdbs/www-data/pdf/RDM0000/DMM0000COL5.pdf?src-supplier=Digi-Key; ComponentLink2URL: https://industrial.panasonic.com/cdbs/www-data/pdf/RDM0000/DMM0000COL5.pdf?src-supplier=Digi-Key; Composition: Thick Film; Features: Automotive AEC-Q200; Height - Seated (Max): 0.028inch; Length: 0.079inch; Max Operating Temperature: 155°C; Min Operating Temperature: -55°C; Number of Terminations: 2; Packaging: Tape and Reel; Pricing 1: 1=0.25000, 10=0.21100, 25=0.15320, 50=0.11100, 100=0.08230, 250=0.06316, 500=0.04976, 1000=0.03445 (USD); RoHS: Yes; Stock 1: 3633; Supplier 1: Digi-Key; Tolerance: 0.5%; Width: 0.049inch; Library Name: Schematic Diagrams.SchLib
  R49 R52: Supplier Part Number 1: P21279CT-ND
R50 = RES 4.7K OHM 1% 1/10W 0603: 4.7k Ohm ±1% 0.1W, 1/10W Chip Resistor 0603 (1608 Metric) Moisture Resistant Thick Film; Manufacturer 1: Yageo; Manufacturer Part Number 1: RC0603FR-074K7L; Description 1: RES SMD 4.7K OHM 1% 1/10W 0603; Temperature Coefficient: ±100ppm/°C; Category: Resistors; Component Kind: Standard; ComponentLink1Description: http://www.yageo.com/documents/recent/PYu-RC_Group_51_RoHS_L_7.pdf; ComponentLink1URL: http://www.yageo.com/documents/recent/PYu-RC_Group_51_RoHS_L_7.pdf; Composition: Thick Film; Failure Rate: -; Features: Moisture Resistant; Height - Seated (Max): 0.022" (0.55mm); Operating Temperature: -55°C ~ 155°C; Package / Case: 0603 (1608 Metric); Packaging: Cut Tape (CT); Part Status: Active; Pricing 1: 1=0.16, 10=0.022, 100=0.0089, 1000=0.00402, 2500=0.00349 (CAD); RoHS: RoHS Compliant; Size / Dimension: 0.063" L x 0.031" W (1.60mm x 0.80mm); Stock 1: 3487566; Supplier 1: Digi-Key; Supplier Device Package: 0603; Supplier Part Number 1: 311-4.70KHRCT-ND; Tolerance: ±1%; Library Name: Schematic Diagrams.SchLib
R51 = RES 1M OHM 1% 1/10W 0603: 1M Ohm ±1% 0.1W, 1/10W Chip Resistor 0603 (1608 Metric) Moisture Resistant Thick Film; Manufacturer 1: Yageo; Manufacturer Part Number 1: RC0603FR-071ML; Description 1: RES SMD 1M OHM 1% 1/10W 0603; Temperature Coefficient: ±100ppm/°C; Category: Resistors; Component Kind: Standard; ComponentLink1Description: http://www.yageo.com/documents/recent/PYu-RC_Group_51_RoHS_L_7.pdf; ComponentLink1URL: http://www.yageo.com/documents/recent/PYu-RC_Group_51_RoHS_L_7.pdf; Composition: Thick Film; Failure Rate: -; Features: Moisture Resistant; Height - Seated (Max): 0.022" (0.55mm); Operating Temperature: -55°C ~ 155°C; Package / Case: 0603 (1608 Metric); Packaging: Cut Tape (CT); Part Status: Active; Power (Watts): 1/10W; Pricing 1: 1=0.16, 10=0.022, 100=0.0089, 1000=0.00402, 2500=0.00349 (CAD); RoHS: RoHS Compliant; Size / Dimension: 0.063" L x 0.031" W (1.60mm x 0.80mm); Stock 1: 2336711; Supplier 1: Digi-Key; Supplier Device Package: 0603; Supplier Part Number 1: 311-1.00MHRCT-ND; Tolerance: ±1%; Library Name: Schematic Diagrams.SchLib
R53 = RES 78.7K OHM 1% 1/10W 0603: 78.7k Ohm ±1% 0.1W, 1/10W Chip Resistor 0603 (1608 Metric) Automotive AEC-Q200 Thick Film; Manufacturer: Panasonic Electronic Components; Manufacturer Part Number: ERJ-3EKF7872V; Temperature Coefficient: ±100ppm/°C; Category: Resistors; Component Kind: Standard; ComponentLink1Description: https://industrial.panasonic.com/cdbs/www-data/pdf/RDA0000/AOA0000C298.pdf; ComponentLink1URL: https://industrial.panasonic.com/cdbs/www-data/pdf/RDA0000/AOA0000C298.pdf; Composition: Thick Film; Failure Rate: -; Features: Automotive AEC-Q200; Height - Seated (Max): 0.022" (0.55mm); Operating Temperature: -55°C ~ 155°C; Package / Case: 0603 (1608 Metric); Packaging: Cut Tape (CT); Part Status: Active; Power (Watts): 1/10W; Resistance (Ohms): 78.7k; RoHS: RoHS Compliant; Size / Dimension: 0.063" L x 0.031" W (1.60mm x 0.80mm); Supplier 1: Digi-Key; Supplier Device Package: 0603; Supplier Part Number 1: P78.7KHCT-ND; Tolerance: ±1%; Library Name: Schematic Diagrams.SchLib
TH_TP1-TH_TP3 = Thru Test Point: Component Kind: Standard; Library Name: Schematic Diagrams.SchLib
TP1 TP4-TP6 TP33 = Test Point: Library Name: Schematic Diagrams.SchLib
U1 = IC CURRENT SENSOR HALL EFFECT 8-SOIC: Current Sensor 10A 1 Channel Hall Effect, Open Loop Unidirectional 8-SOIC (0.154", 3.90mm Width); Manufacturer: Allegro MicroSystems, LLC; Accuracy: ±3%; Current - Supply (Max): 12mA; For Measuring: DC; Frequency: DC ~ 80kHz; Linearity: ±1%; Output: Ratiometric, Voltage; Response Time: 5µs; Sensitivity: 264mV/A; Voltage - Supply: 3 V ~ 3.6 V; Category: Sensors, Transducers; Component Kind: Standard; ComponentLink1Description: http://www.allegromicro.com/~/media/Files/Datasheets/ACS722-Datasheet.ashx; ComponentLink1URL: http://www.allegromicro.com/~/media/Files/Datasheets/ACS722-Datasheet.ashx; Current - Sensing: 10A; Mounting Type: Surface Mount; Operating Temperature: -40°C ~ 150°C; Package / Case: 8-SOIC (0.154", 3.90mm Width); Packaging: Cut Tape (CT); Part Status: Active; Polarization: Unidirectional; Pricing 1: 1=8.22, 5=7.25, 10=6.404, 25=5.1948, 50=4.5908, 100=4.4701, 500=3.74526, 1000=3.50362 (CAD); RoHS: RoHS Compliant; Sensor Type: Hall Effect, Open Loop; Stock 1: 5711; Supplier 1: Digi-Key; Supplier Part Number 1: 620-1636-1-ND; Library Name: Schematic Diagrams.SchLib
U4 = IC PWR DRIVER N-CHAN 1:1 14TSSOP: IC PWM SOLENOID DRVR 8TSSOP; Manufacturer: Texas Instruments; Fault Protection: Over Temperature; Interface: On/Off; Number of Outputs: 1; Operating Supply Voltage: 26V; Output Configuration: Low Side; Output Current: 160mA; Case/Package: TSSOP; Category: Amplifiers - Op Amps, Buffer, Instrumentation; Component Kind: Standard; ComponentLink1Description: https://datasheet.ciiva.com/26931/getdatasheetpartid-613822-26931129.pdf?src-supplier=Verical; ComponentLink1URL: https://datasheet.ciiva.com/26931/getdatasheetpartid-613822-26931129.pdf?src-supplier=Verical; ComponentLink2Description: https://datasheet.ciiva.com/6609/drv120-6609494.pdf?src-supplier=Digi-Key; ComponentLink2URL: https://datasheet.ciiva.com/6609/drv120-6609494.pdf?src-supplier=Digi-Key; ComponentLink3Description: https://datasheet.ciiva.com/6609/drv120-6609493.pdf?src-supplier=Digi-Key; ComponentLink3URL: https://datasheet.ciiva.com/6609/drv120-6609493.pdf?src-supplier=Digi-Key; Lead Free: Lead Free; Length: 3.1mm; Max Operating Temperature: 105°C; Min Operating Temperature: -40°C; Mount: Surface Mount; Number of Pins: 8; Package Quantity: 2000; Packaging: Tape and Reel; Pricing 1: 1=2.43000, 10=2.18400, 25=2.06000, 100=1.64800, 250=1.44200, 500=1.40080, 1000=1.11240 (USD); Radiation Hardening: No; RoHS: Yes; Stock 1: 1985; Supplier 1: Digi-Key; Supplier Part Number 1: 296-34777-1-ND
U29 = IC LTC4359HS8#PBF IDEAL DIODE CONTROLLER WITH REVE: Voltage Detector IC MSOP-8, LTC4359IMS8#PBF, Linear Technology; Manufacturer: Analog Devices / Linear Technology; Max Output Current: 180mA; Max Supply Voltage: 80V; Min Supply Voltage: 4V; Nominal Supply Current: 150uA; Number of Drivers: 1; Turn-Off Delay Time: 500ns; Case/Package: MSOP; Category: Voltage Supervisors; Component Kind: Standard; ComponentLink1Description: https://datasheet.ciiva.com/6609/4359fb-6609591.pdf?src-supplier=Digi-Key; ComponentLink1URL: https://datasheet.ciiva.com/6609/4359fb-6609591.pdf?src-supplier=Digi-Key; Lead Free: Lead Free; Manufacturer Part Number: LTC4359IMS8#PBF; Max Operating Temperature: 85°C; Min Operating Temperature: -40°C; Number of Outputs: 1; Number of Pins: 8; Package Quantity: 50; Pricing 1: 1=5.87000, 25=3.89440, 100=3.22000 (USD); RoHS: Yes; Stock 1: 3812; Supplier 1: Digi-Key; Library Name: Schematic Diagrams.SchLib
